# Altium schematic sheet PN5180 R1.1-170710.SchDoc
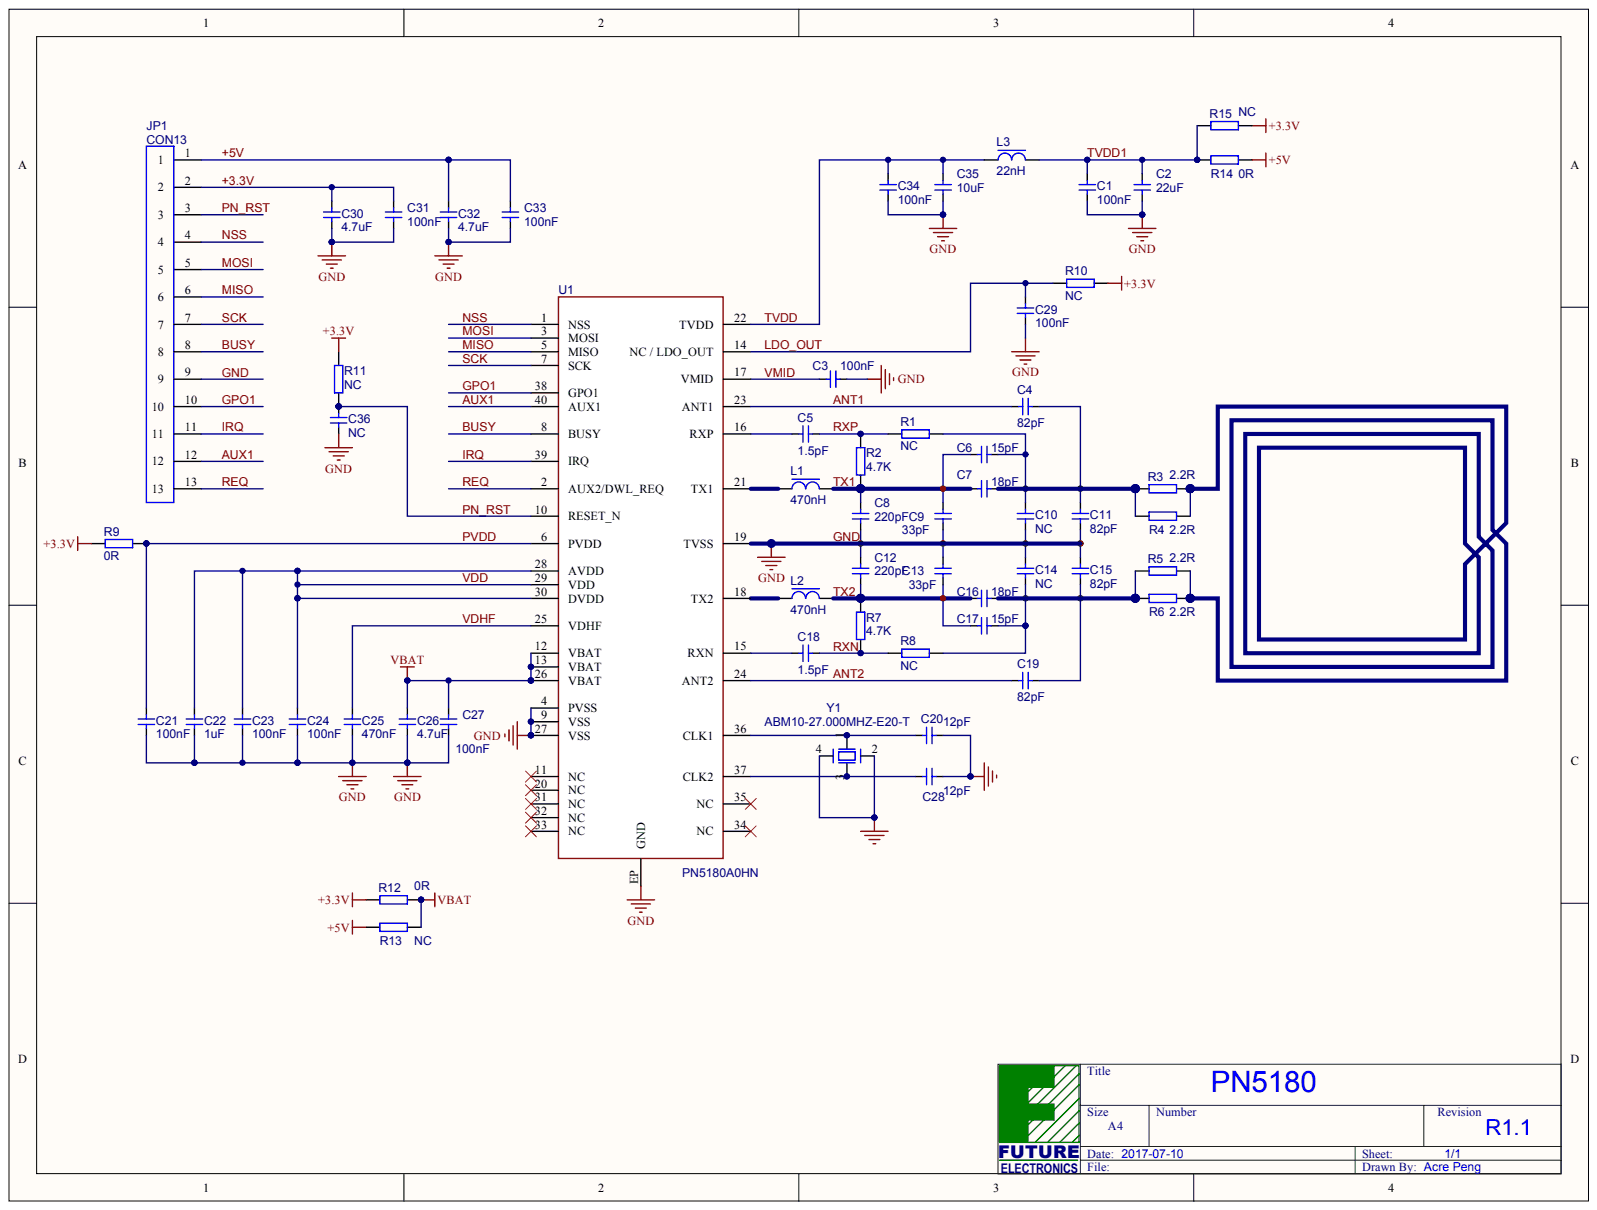
[diagram p0: PN5180 R1.1-170710.SchDoc]

## Parts
C1  100nF
C2  22uF
C3  100nF
C4  82pF
C5  1.5pF
C6  15pF
C7  18pF
C8  220pF
C9  33pF
C10  NC
C11  82pF
C12  220pF
C13  33pF
C14  NC
C15  82pF
C16  18pF
C17  15pF
C18  1.5pF
C19  82pF
C20  12pF
C21  100nF
C22  1uF
C23  100nF
C24  100nF
C25  470nF
C26  4.7uF
C27  100nF
C28  12pF
C29  100nF
C30  4.7uF
C31  100nF
C32  4.7uF
C33  100nF
C34  100nF
C35  10uF
C36  NC
JP1  CON13
L1  470nH
L2  470nH
L3  22nH
R1  NC
R2  4.7K
R3  2.2R
R4  2.2R
R5  2.2R
R6  2.2R
R7  4.7K
R8  NC
R9  0R
R10  NC
R11  NC
R12  0R
R13  NC
R14  0R
R15  NC
U1  PN5180A0HN
Y1  ABM10-27.000MHZ-E20-T

## Nets
VBAT: C26.2 C27.2 R12.1 R13.1 U1.12(VBAT) U1.13(VBAT) U1.26(VBAT)
+3.3V: C30.2 C31.2 JP1.2 R9.2 R10.1 R11.2 R12.2 R15.1
+5V: C32.2 C33.2 JP1.1 R13.2 R14.1
ANT1: C4.1 U1.23(ANT1)
ANT2: C19.1 U1.24(ANT2)
AUX1: JP1.12 U1.40(AUX1)
BUSY: JP1.8 U1.8(BUSY)
GND: C1.1 C2.1 C3.2 C8.2 C9.2 C10.2 C11.2 C12.1 C13.1 C14.1 C15.1 C20.1 C21.1 C22.1 C23.1 C24.1 C25.1 C26.1 C27.1 C28.1 C29.1 C30.1 C31.1 C32.1 C33.1 C34.1 C35.1 C36.1 JP1.9 U1.4(PVSS) U1.9(VSS) U1.19(TVSS) U1.27(VSS) U1.EP(GND) Y1.2 Y1.4
GPO1: JP1.10 U1.38(GPO1)
IRQ: JP1.11 U1.39(IRQ)
LDO_OUT: C29.2 R10.2 U1.14
MISO: JP1.6 U1.5(MISO)
MOSI: JP1.5 U1.3(MOSI)
NSS: JP1.4 U1.1(NSS)
PN_RST: C36.2 JP1.3 R11.1 U1.10(RESET_N)
PVDD: C21.2 R9.1 U1.6(PVDD)
REQ: JP1.13 U1.2(AUX2/DWL_REQ)
RXN: C18.2 R7.2 R8.1
RXP: C5.2 R1.1 R2.1
SCK: JP1.7 U1.7(SCK)
TVDD: C34.2 C35.2 L3.1 U1.22(TVDD)
TVDD1: C1.2 C2.2 L3.2 R14.2 R15.2
TX1: C6.1 C7.1 C8.1 C9.1 L1.2 R2.2
TX2: C12.2 C13.2 C16.1 C17.1 L2.2 R7.1
VDD: C22.2 C23.2 C24.2 U1.28(AVDD) U1.29(VDD) U1.30(DVDD)
VDHF: C25.2 U1.25(VDHF)
VMID: C3.1 U1.17(VMID)
NetC4_2: C4.2 C6.2 C7.2 C10.1 C11.1 R1.2 R3.1 R4.1
NetC5_1: C5.1 U1.16(RXP)
NetC14_2: C14.2 C15.2 C16.2 C17.2 C19.2 R5.1 R6.1 R8.2
NetC18_1: C18.1 U1.15(RXN)
NetC20_2: C20.2 U1.36(CLK1) Y1.1
NetC28_2: C28.2 U1.37(CLK2) Y1.3
NetL1_1: L1.1 U1.21(TX1)
NetL2_1: L2.1 U1.18(TX2)
NetR3_2: R3.2 R4.2 R5.2 R6.2

Not connected: U1 11(NC) 20(NC) 31(NC) 32(NC) 33(NC) 34(NC) 35(NC)
